# Altium schematic sheet REV0.3.SchDoc
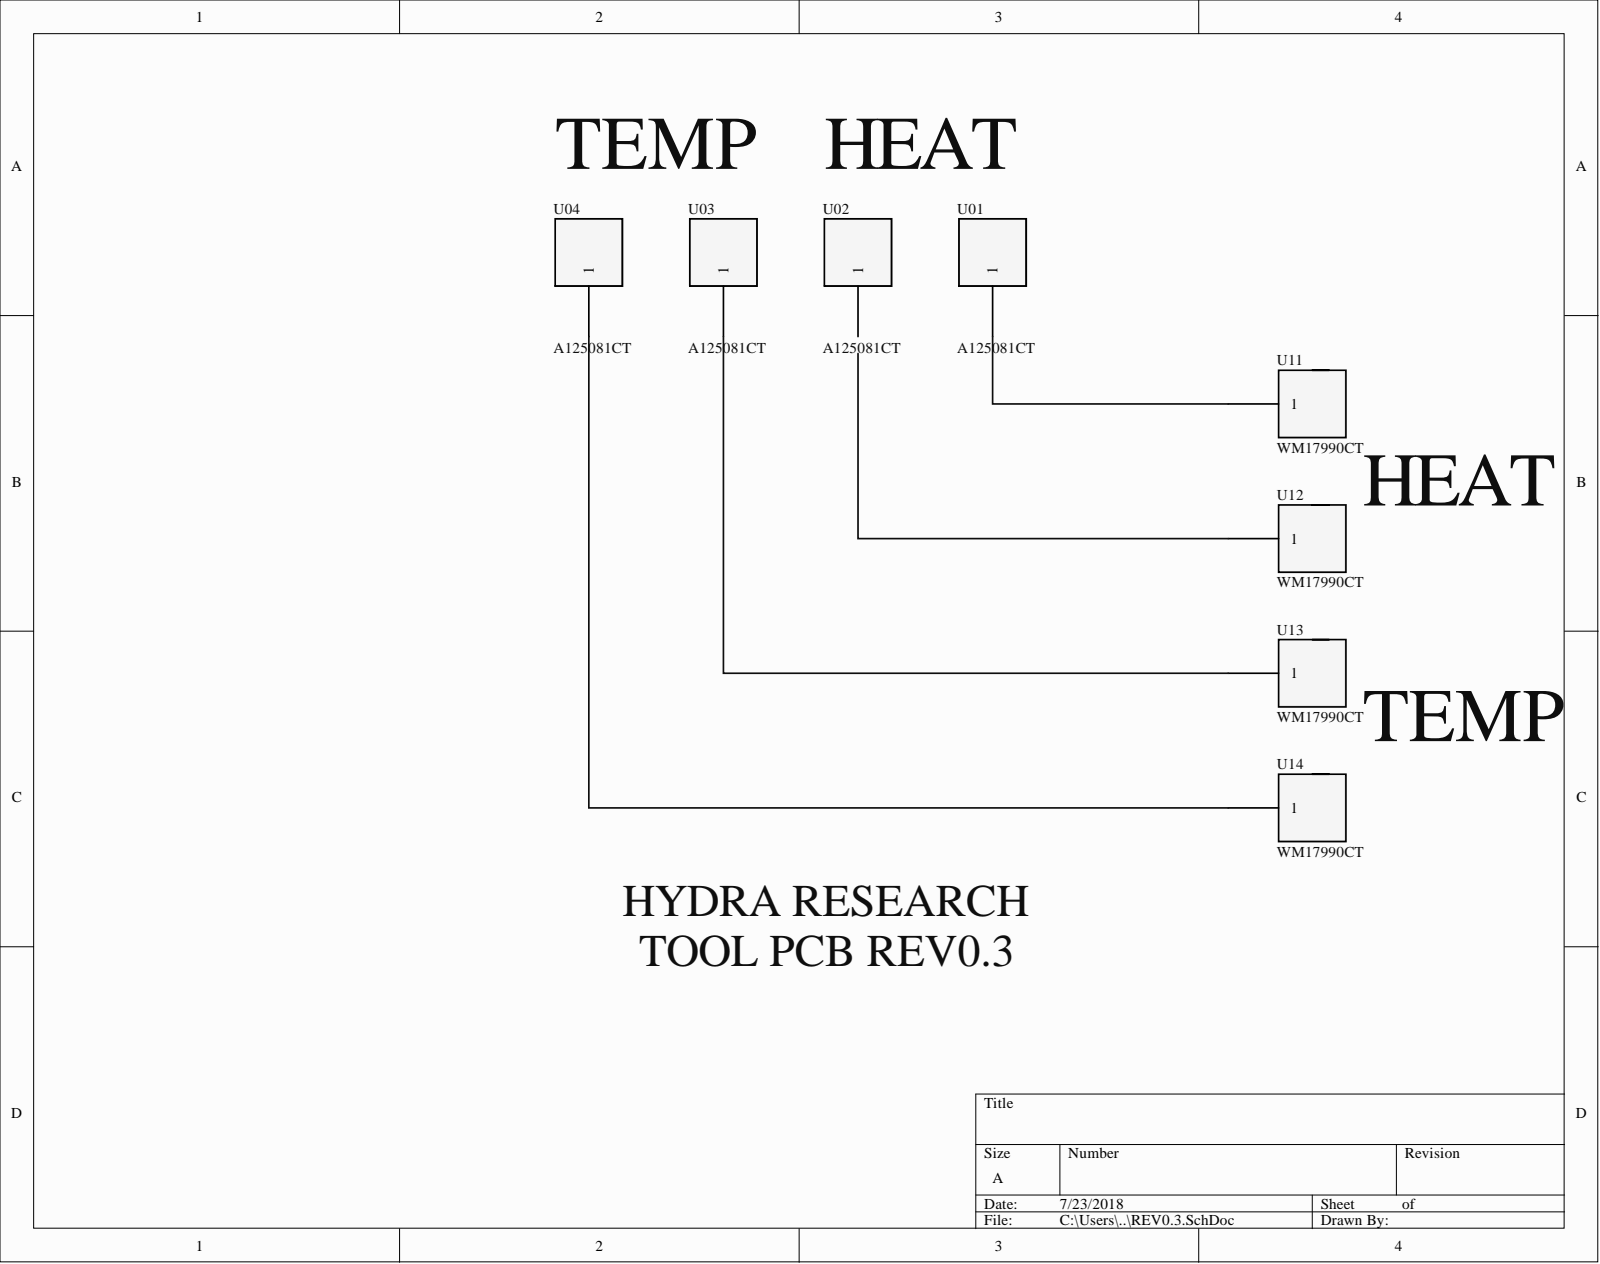
[diagram p0: REV0.3.SchDoc]

## Parts
U01  A125081CT  [A125081CT-ND]
U02  A125081CT  [A125081CT-ND]
U03  A125081CT  [A125081CT-ND]
U04  A125081CT  [A125081CT-ND]
U11  WM17990CT  [WM17990CT-ND-l]
U12  WM17990CT  [WM17990CT-ND-l]
U13  WM17990CT  [WM17990CT-ND-l]
U14  WM17990CT  [WM17990CT-ND-l]

## Nets
NetU01_?: U01.? U11.?
NetU03_?: U03.? U13.?
NetU04_?: U04.? U14.?

Not connected: U02 ?; U12 ?

## Library data of the parts
U11-U14 = WM17990CT: MPN: WM17990CT-ND; Component Kind: Standard; Library Name: Schlib1.SchLib
U01 U02 U03 U04 = A125081CT: Component Kind: Standard; Library Name: Schlib1.SchLib; MPN: A125081CT-ND
